# Altium schematic sheet SLCD.SchDoc
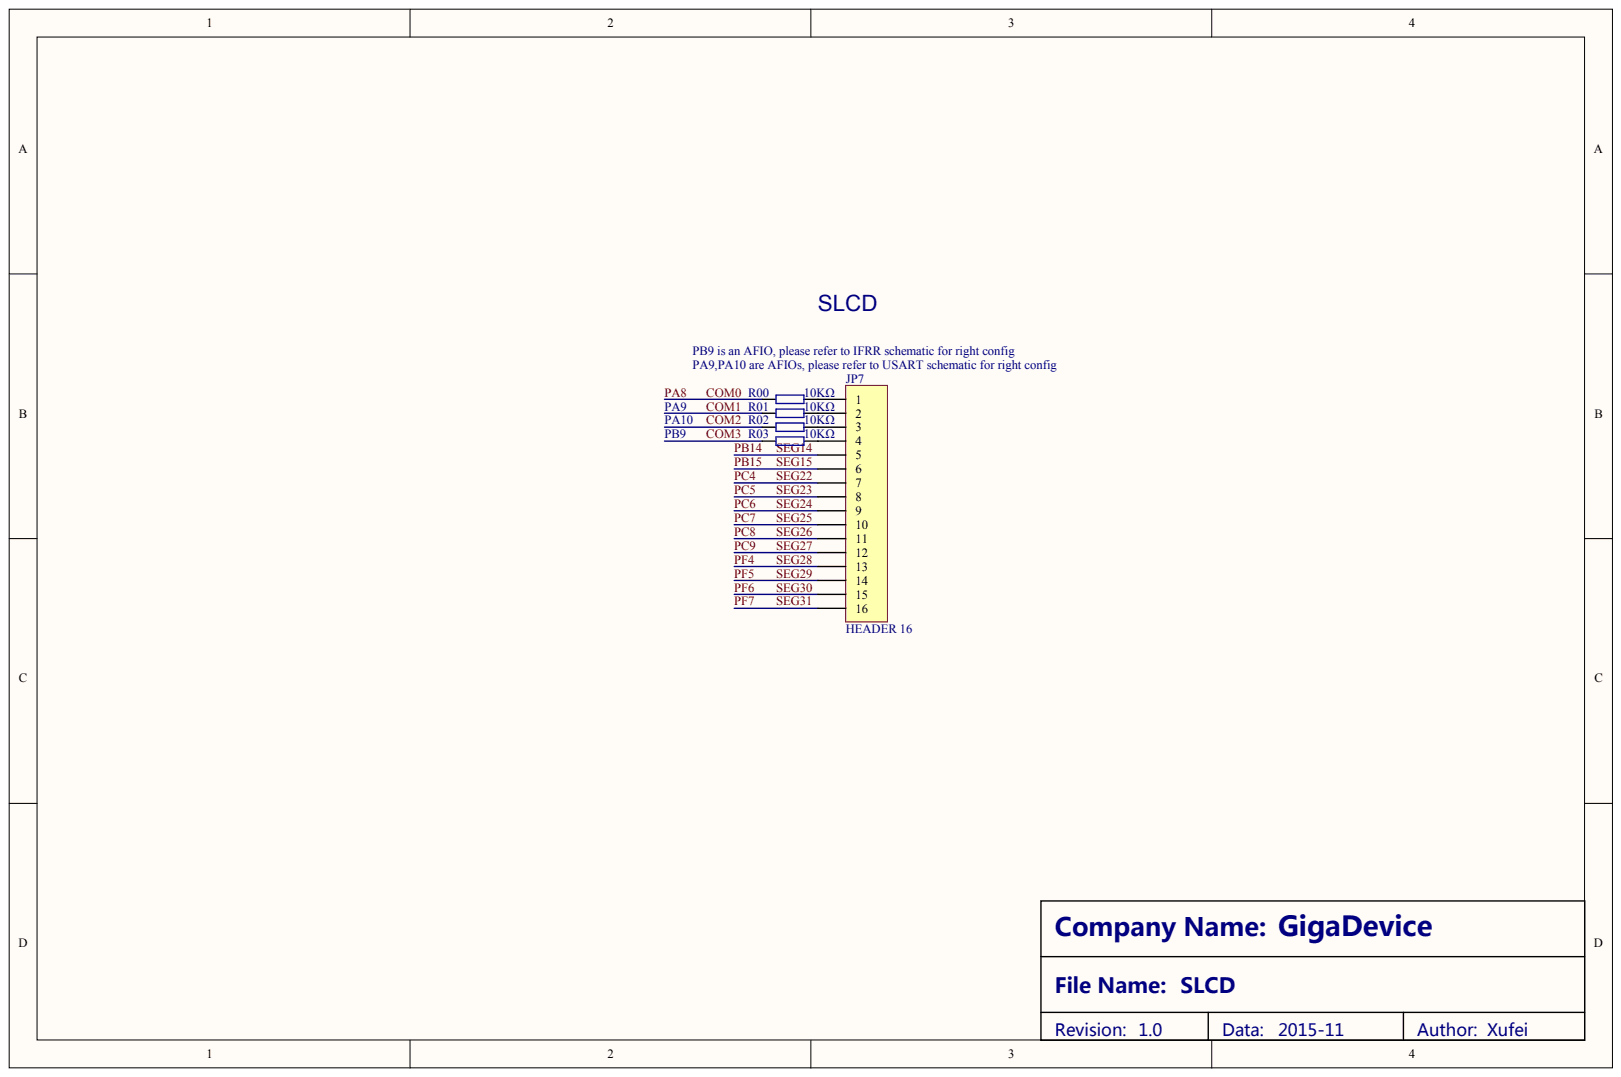
[diagram p0: SLCD.SchDoc]

## Parts
JP7  HEADER 16
R00  10KΩ
R01  10KΩ
R02  10KΩ
R03  10KΩ

## Nets
COM0: R00.1
COM1: R01.1
COM2: R02.1
COM3: R03.1
PB14: JP7.5
PB15: JP7.6
PC4: JP7.7
PC5: JP7.8
PC6: JP7.9
PC7: JP7.10
PC8: JP7.11
PC9: JP7.12
PF4: JP7.13
PF5: JP7.14
PF6: JP7.15
PF7: JP7.16
NetJP7_1: JP7.1 R00.2
NetJP7_2: JP7.2 R01.2
NetJP7_3: JP7.3 R02.2
NetJP7_4: JP7.4 R03.2
